# Altium schematic sheet 01_POWER.SchDoc
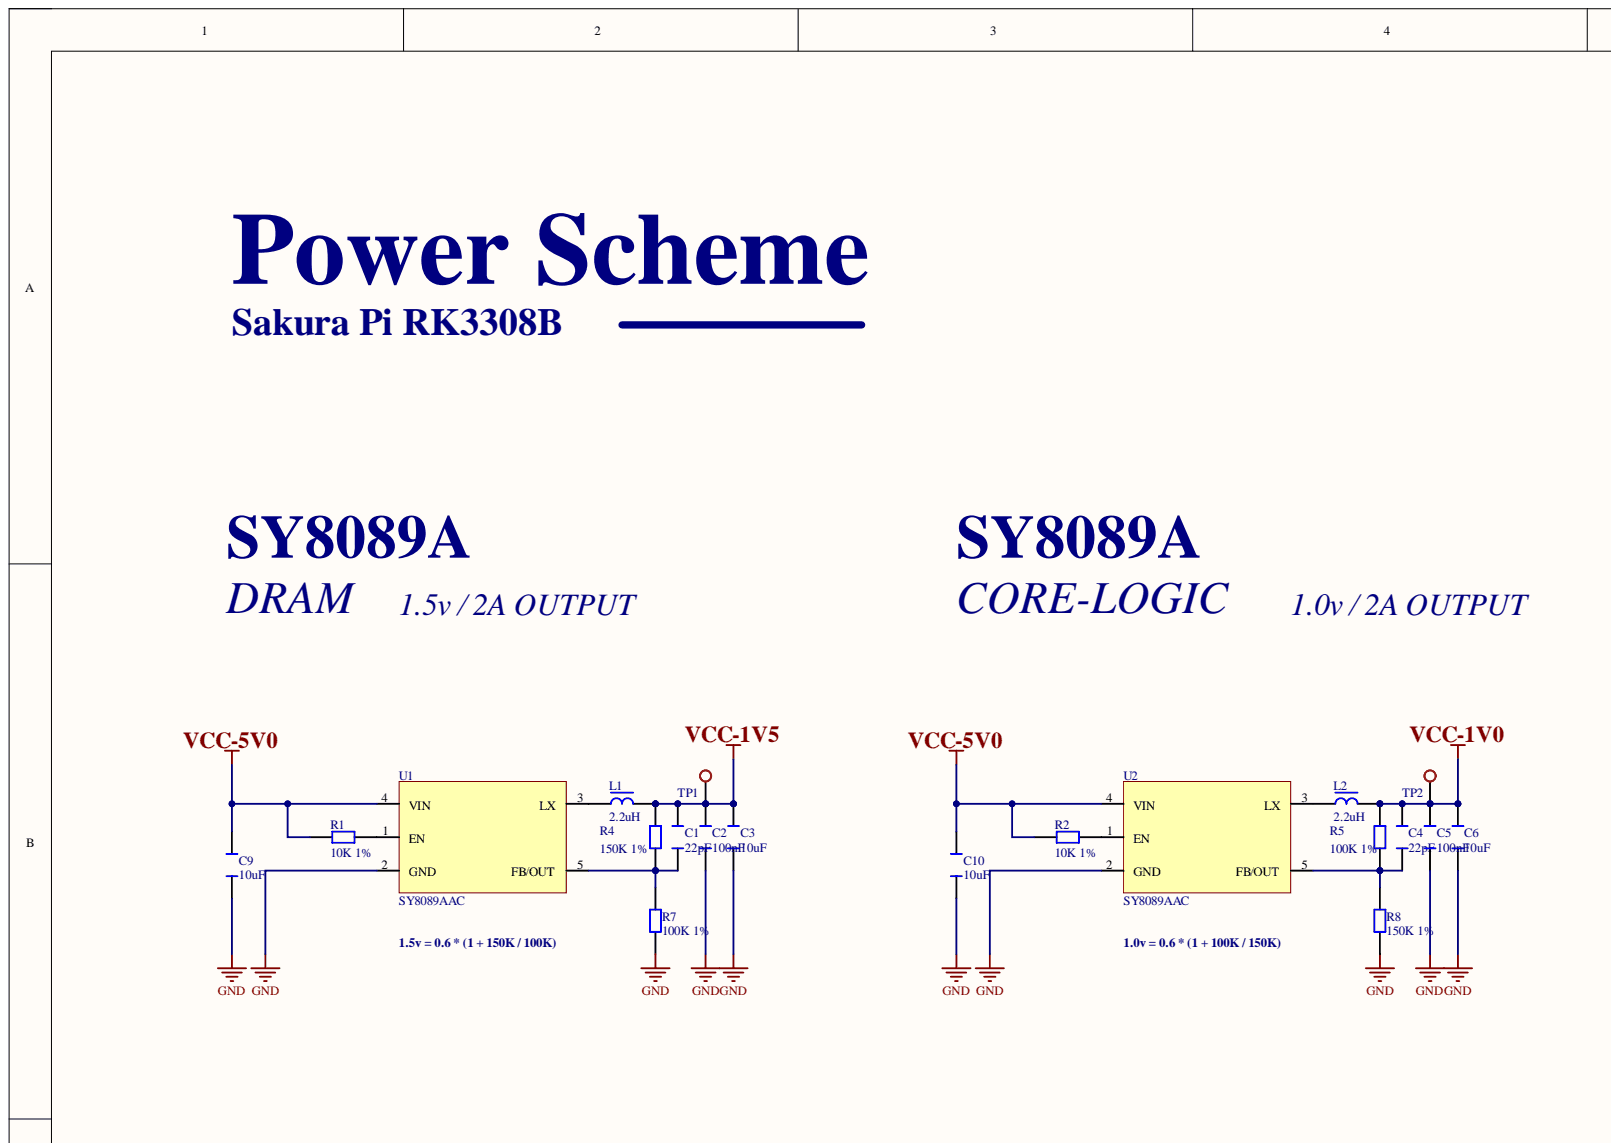
[diagram p0: 01_POWER.SchDoc, top left]
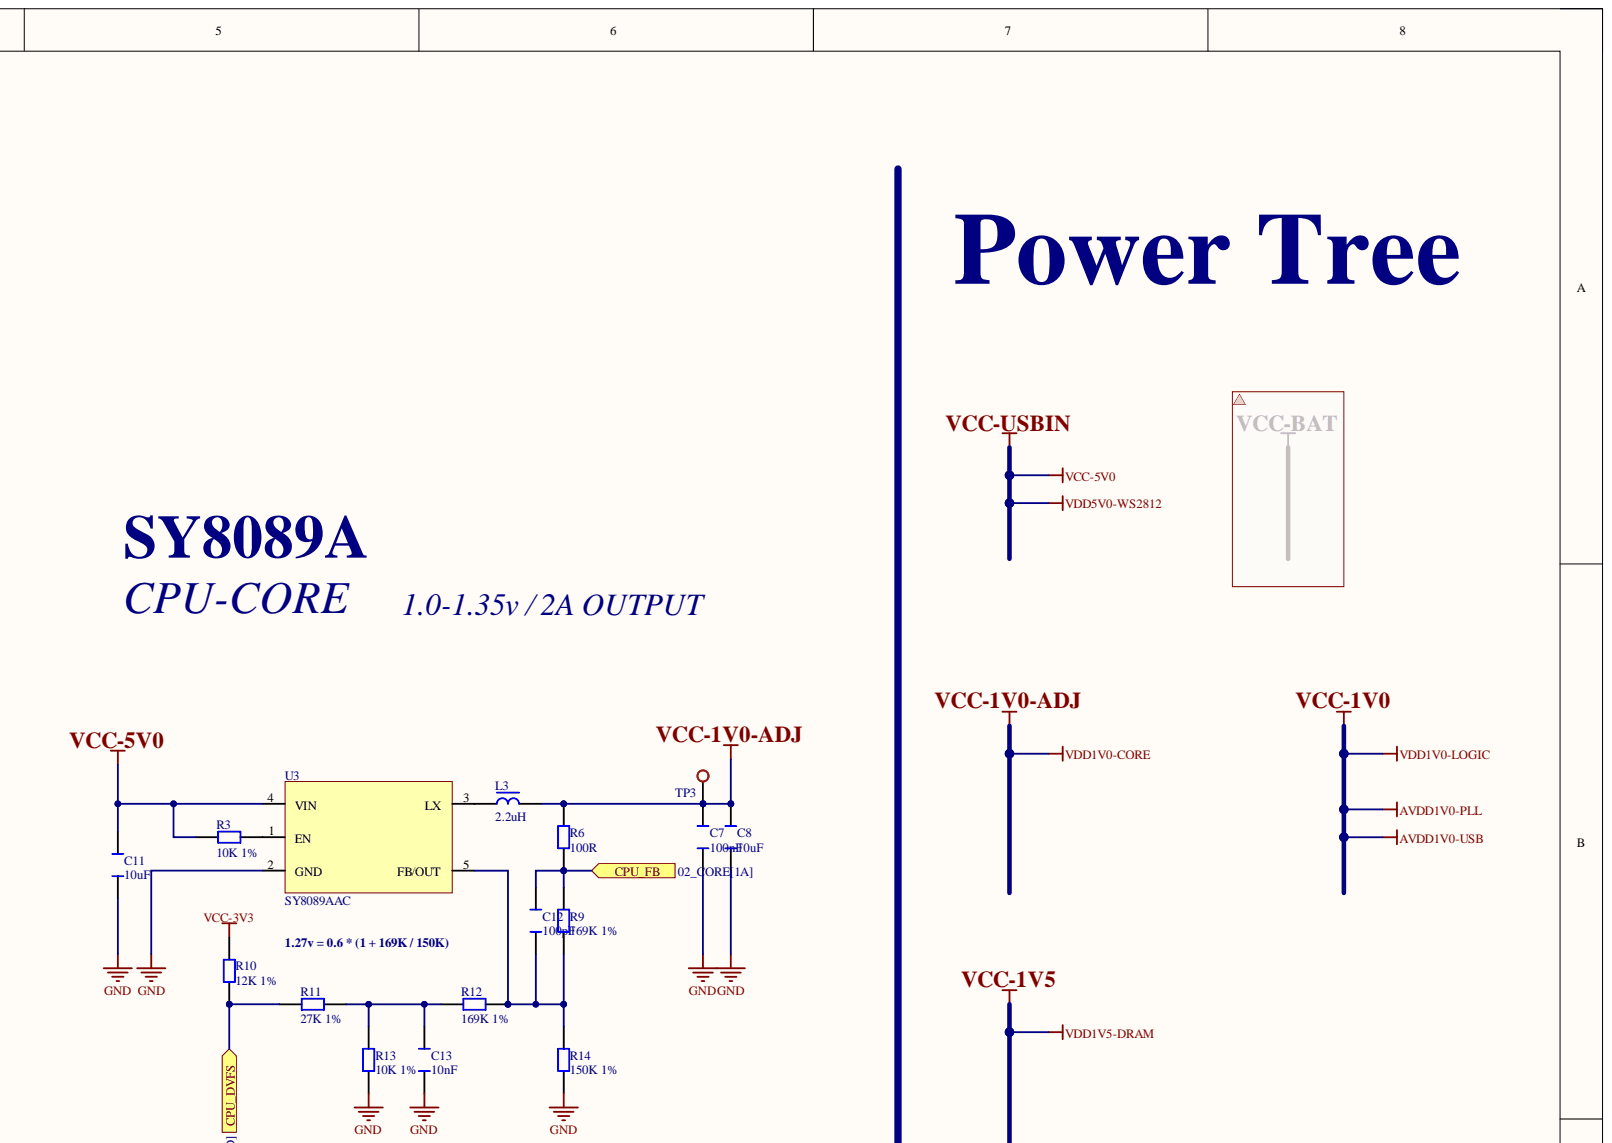
[diagram p1: 01_POWER.SchDoc, top right]
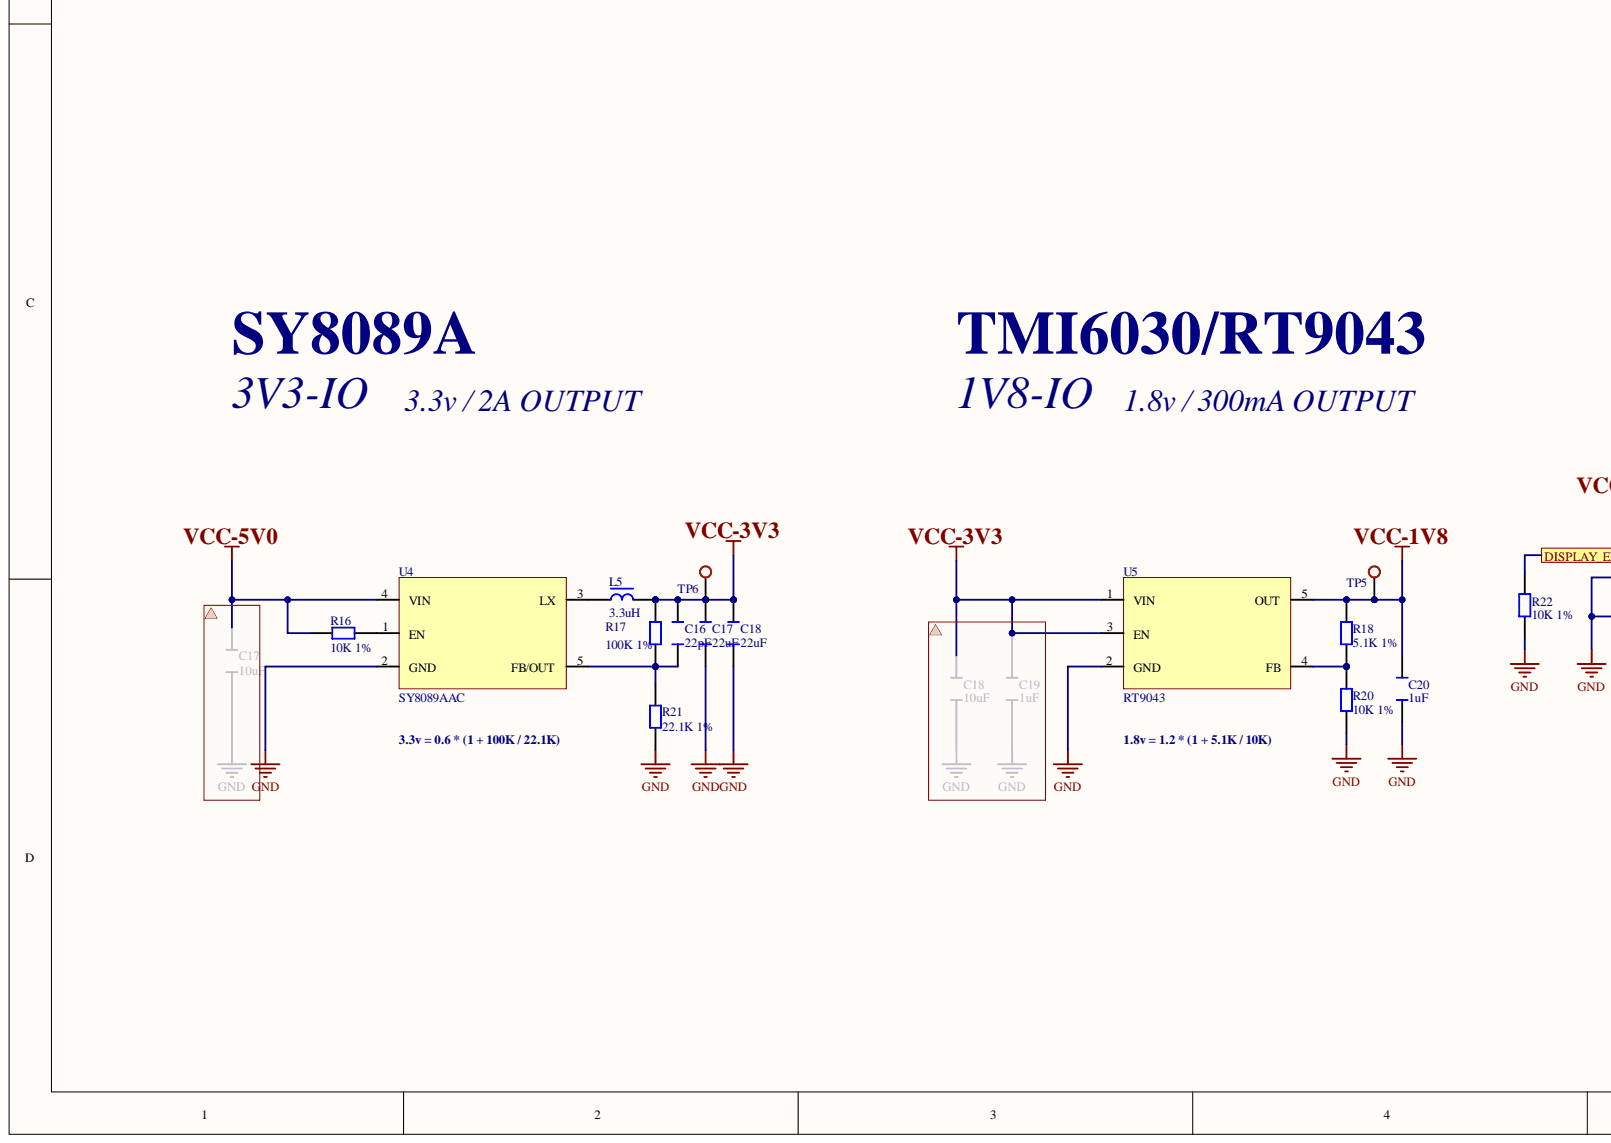
[diagram p2: 01_POWER.SchDoc, bottom left]
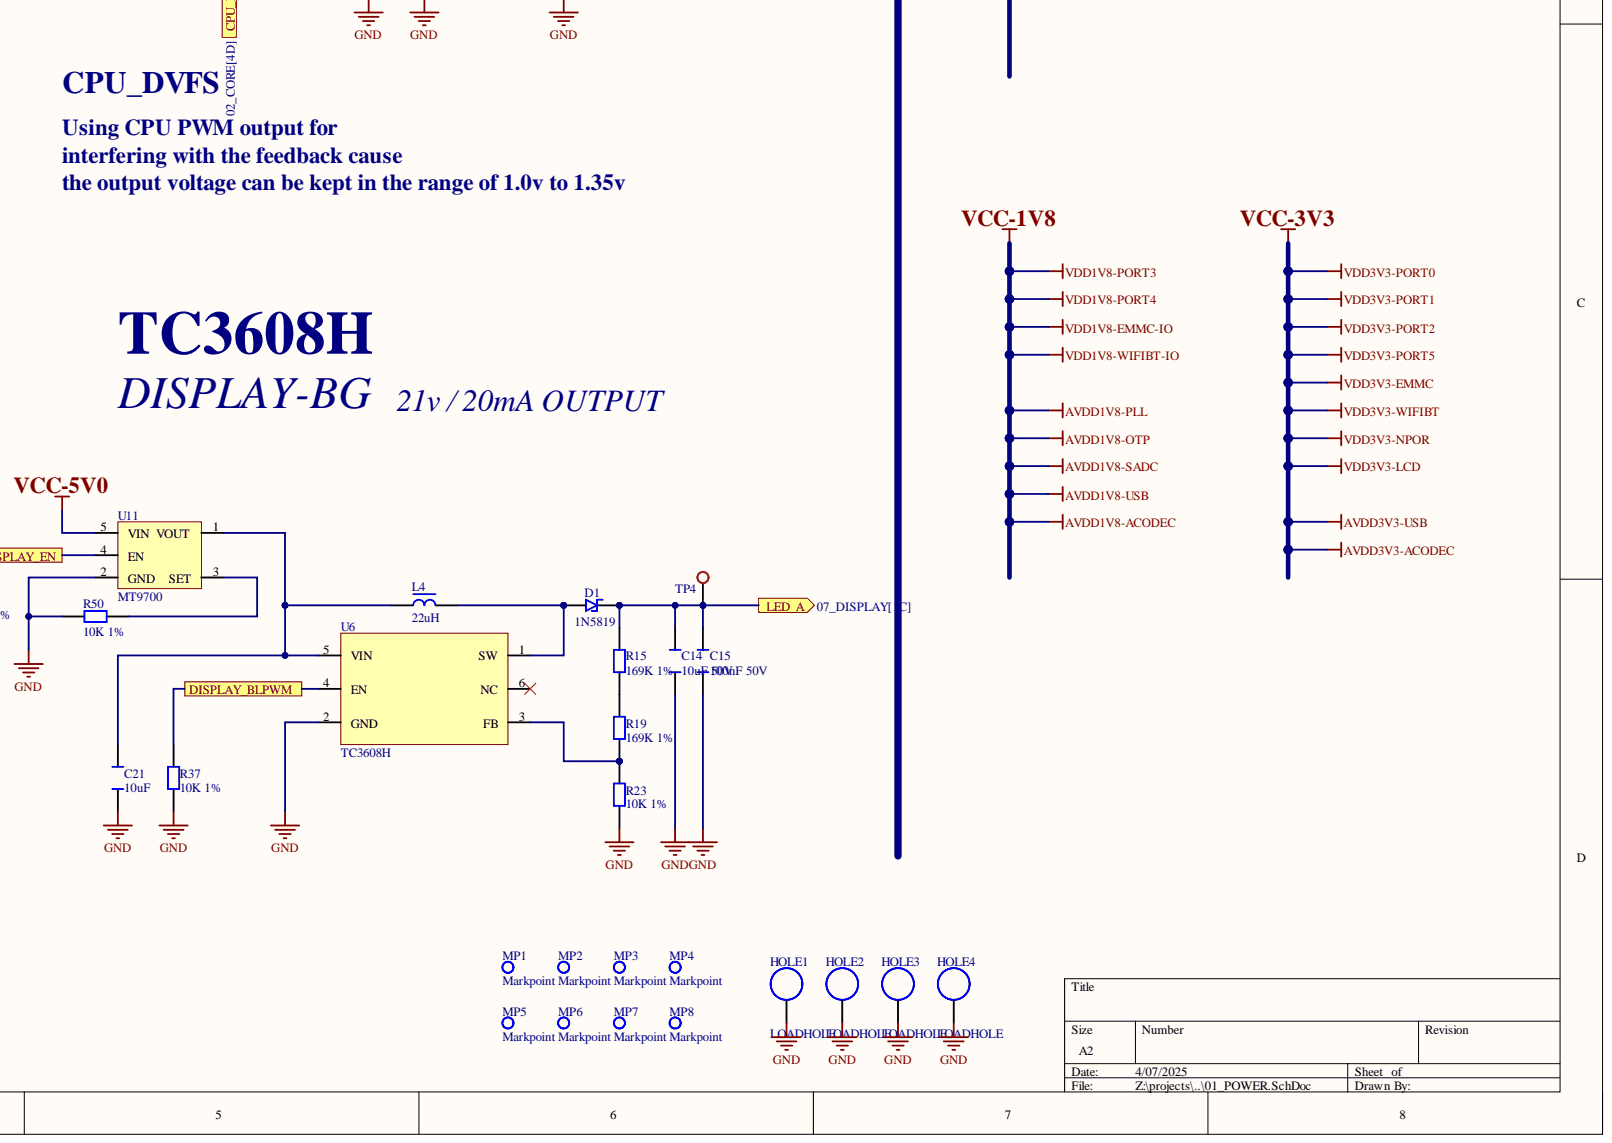
[diagram p3: 01_POWER.SchDoc, bottom right]

## Parts
C1  22pF  [C0402]
C2  100nF  [C0603]
C3  10uF  [C0603]
C4  22pF  [C0402]
C5  100nF  [C0603]
C6  10uF  [C0603]
C7  100nF  [C0603]
C8  10uF  [C0603]
C9  10uF  [C0603]
C10  10uF  [C0603]
C11  10uF  [C0603]
C12  100pF  [C0402]
C13  10nF  [C0402]
C14  10uF 50V  [C0603]
C15  100nF 50V  [C0603]
C16  22pF  [C0402]
C17  22uF  [C0603]
C18  22uF  [C0603]
C20  1uF  [C0603]
C21  10uF  [C0603]
D1  1N5819  [SOD-323]
HOLE1  LOADHOLE  [LOADHOLE]
HOLE2  LOADHOLE  [LOADHOLE]
HOLE3  LOADHOLE  [LOADHOLE]
HOLE4  LOADHOLE  [LOADHOLE]
L1  2.2uH  [L2520]
L2  2.2uH  [L2520]
L3  2.2uH  [L2520]
L4  22uH  [L0603]
L5  3.3uH  [L2520]
MP1  Markpoint  [MARKPOINT]
MP2  Markpoint  [MARKPOINT]
MP3  Markpoint  [MARKPOINT]
MP4  Markpoint  [MARKPOINT]
MP5  Markpoint  [MARKPOINT]
MP6  Markpoint  [MARKPOINT]
MP7  Markpoint  [MARKPOINT]
MP8  Markpoint  [MARKPOINT]
R1  10K 1%  [R0402]
R2  10K 1%  [R0402]
R3  10K 1%  [R0402]
R4  150K 1%  [R0402]
R5  100K 1%  [R0402]
R6  100R  [R0402]
R7  100K 1%  [R0402]
R8  150K 1%  [R0402]
R9  169K 1%  [R0402]
R10  12K 1%  [R0402]
R11  27K 1%  [R0402]
R12  169K 1%  [R0402]
R13  10K 1%  [R0402]
R14  150K 1%  [R0402]
R15  169K 1%  [R0402]
R16  10K 1%  [R0402]
R17  100K 1%  [R0402]
R18  5.1K 1%  [R0402]
R19  169K 1%  [R0402]
R20  10K 1%  [R0402]
R21  22.1K 1%  [R0402]
R22  10K 1%  [R0402]
R23  10K 1%  [R0402]
R37  10K 1%  [R0402]
R50  10K 1%  [R0402]
TP1  Test point  [TP]  (value not drawn)
TP2  Test point  [TP]  (value not drawn)
TP3  Test point  [TP]  (value not drawn)
TP4  Test point  [TP]  (value not drawn)
TP5  Test point  [TP]  (value not drawn)
TP6  Test point  [TP]  (value not drawn)
U1  SY8089AAC  [SOT95P280X145-5N]
U2  SY8089AAC  [SOT95P280X145-5N]
U3  SY8089AAC  [SOT95P280X145-5N]
U4  SY8089AAC  [SOT95P280X145-5N]
U5  RT9043  [SOT95P280X145-5N]
U6  TC3608H  [TC3608H]
U11  MT9700  [SOT95P280X145-5N]

## Ports
CPU_DVFS, CPU_FB, DISPLAY_BLPWM, DISPLAY_EN, LED_A

## Nets
GND: C2.1 C3.2 C5.1 C6.2 C7.1 C8.2 C9.1 C10.1 C11.1 C13.1 C14.1 C15.1 C17.2 C18.2 C20.1 C21.1 HOLE1.1 HOLE2.1 HOLE3.1 HOLE4.1 R7.2 R8.2 R13.1 R14.1 R20.1 R21.2 R22.1 R23.1 R37.2 R50.2 U1.2(GND) U2.2(GND) U3.2(GND) U4.2(GND) U5.2(GND) U6.2(GND) U11.2(GND)
CPU_DVFS: R10.1 R11.1 ; port CPU_DVFS
CPU_FB: C12.2 R6.1 R9.2 ; port CPU_FB
DISPLAY_BLPWM: R37.1 U6.4(EN) ; port DISPLAY_BLPWM
DISPLAY_EN: R22.2 U11.4(EN) ; port DISPLAY_EN
LED_A: C14.2 C15.2 D1.2 R15.2 TP4.1 ; port LED_A
VCC-1V0: C4.2 C5.2 C6.1 L2.2 R5.2 TP2.1
VCC-1V0-ADJ: C7.2 C8.1 L3.2 R6.2 TP3.1
VCC-1V5: C1.2 C2.2 C3.1 L1.2 R4.2 TP1.1
VCC-1V8: C20.2 R18.2 TP5.1 U5.5(OUT)
VCC-3V3: C16.2 C17.1 C18.1 L5.2 R10.2 R17.2 TP6.1 U5.1(VIN) U5.3(EN)
VCC-5V0: C9.2 C10.2 C11.2 R1.1 R2.1 R3.1 R16.1 U1.4(VIN) U2.4(VIN) U3.4(VIN) U4.4(VIN) U11.5(VIN)
NetC1_1: C1.1 R4.1 R7.1 U1.5(FB/OUT)
NetC4_1: C4.1 R5.1 R8.1 U2.5(FB/OUT)
NetC12_1: C12.1 R9.1 R12.2 R14.2 U3.5(FB/OUT)
NetC13_2: C13.2 R11.2 R12.1 R13.2
NetC16_1: C16.1 R17.1 R21.1 U4.5(FB/OUT)
NetC21_2: C21.2 L4.1 U6.5(VIN) U11.1(VOUT)
NetD1_1: D1.1 L4.2 U6.1(SW)
NetL1_1: L1.1 U1.3(LX)
NetL2_1: L2.1 U2.3(LX)
NetL3_1: L3.1 U3.3(LX)
NetL5_1: L5.1 U4.3(LX)
NetR1_2: R1.2 U1.1(EN)
NetR2_2: R2.2 U2.1(EN)
NetR3_2: R3.2 U3.1(EN)
NetR15_1: R15.1 R19.2
NetR16_2: R16.2 U4.1(EN)
NetR18_1: R18.1 R20.2 U5.4(FB)
NetR19_1: R19.1 R23.2 U6.3(FB)
NetR50_1: R50.1 U11.3(SET)

Not connected: U6 6(NC)

## Library data of the parts
C1 C4 C16 = C_22PF: Component Kind: Standard; Library Name: rk3308b.SchLib
C2 C5 C7 = C_100NF: Component Kind: Standard; Library Name: rk3308b.SchLib
C3 C6 C8-C11 C21 = C_10UF: Component Kind: Standard; Library Name: rk3308b.SchLib
C12 = C_100PF: Component Kind: Standard; Library Name: rk3308b.SchLib
C13 = C_10NF: Component Kind: Standard; Library Name: rk3308b.SchLib
C14 = C_10UF_50V: Component Kind: Standard; Library Name: rk3308b.SchLib
C15 = C_100NF_50V: Component Kind: Standard; Library Name: rk3308b.SchLib
C17 C18 = C_22UF: Component Kind: Standard; Library Name: rk3308b.SchLib
C20 = C_1UF: Component Kind: Standard; Library Name: rk3308b.SchLib
L1-L3 = L_2.2UH: Component Kind: Standard; Library Name: rk3308b.SchLib
L4 = L_22UH: Component Kind: Standard; Library Name: rk3308b.SchLib
L5 = L_3.3UH: Component Kind: Standard; Library Name: rk3308b.SchLib
R1-R3 R13 R16 R20 R22 R23 R37 R50 = R_10K_P1: Component Kind: Standard; Library Name: rk3308b.SchLib
R4 R8 R14 = R_150K_P1: Component Kind: Standard; Library Name: rk3308b.SchLib
R5 R7 R17 = R_100K_P1: Component Kind: Standard; Library Name: rk3308b.SchLib
R6 = R_100R: Component Kind: Standard; Library Name: rk3308b.SchLib
R9 R12 R15 R19 = R_169K_P1: Component Kind: Standard; Library Name: rk3308b.SchLib
R10 = R_12K_P1: Component Kind: Standard; Library Name: rk3308b.SchLib
R11 = R_27K_P1: Component Kind: Standard; Library Name: rk3308b.SchLib
R18 = R_5.1K: Component Kind: Standard; Library Name: rk3308b.SchLib
R21 = R_22.1K_P1: Component Kind: Standard; Library Name: rk3308b.SchLib
TP1-TP6 = TP: Component Kind: Standard; Library Name: rk3308b.SchLib
U6 = TC3608H: High efficiency 1.2MHz DC-DC Boost IC; Component Kind: Standard; Library Name: rk3308b.SchLib
D1 = 1N5819: Component Kind: Standard; Library Name: rk3308b.SchLib
HOLE1-HOLE4 = LOADHOLE: Component Kind: Standard; Library Name: rk3308b.SchLib
MP1-MP8 = MARKPOINT: Component Kind: Standard; Library Name: rk3308b.SchLib
U1-U4 = SY8089AAC: Component Kind: Standard; Library Name: rk3308b.SchLib
U5 = RT9043: Component Kind: Standard; Library Name: rk3308b.SchLib
U11 = MT9700: Component Kind: Standard; Library Name: rk3308b.SchLib
